# Altium schematic sheet 03_BB9900Keypad.SchDoc
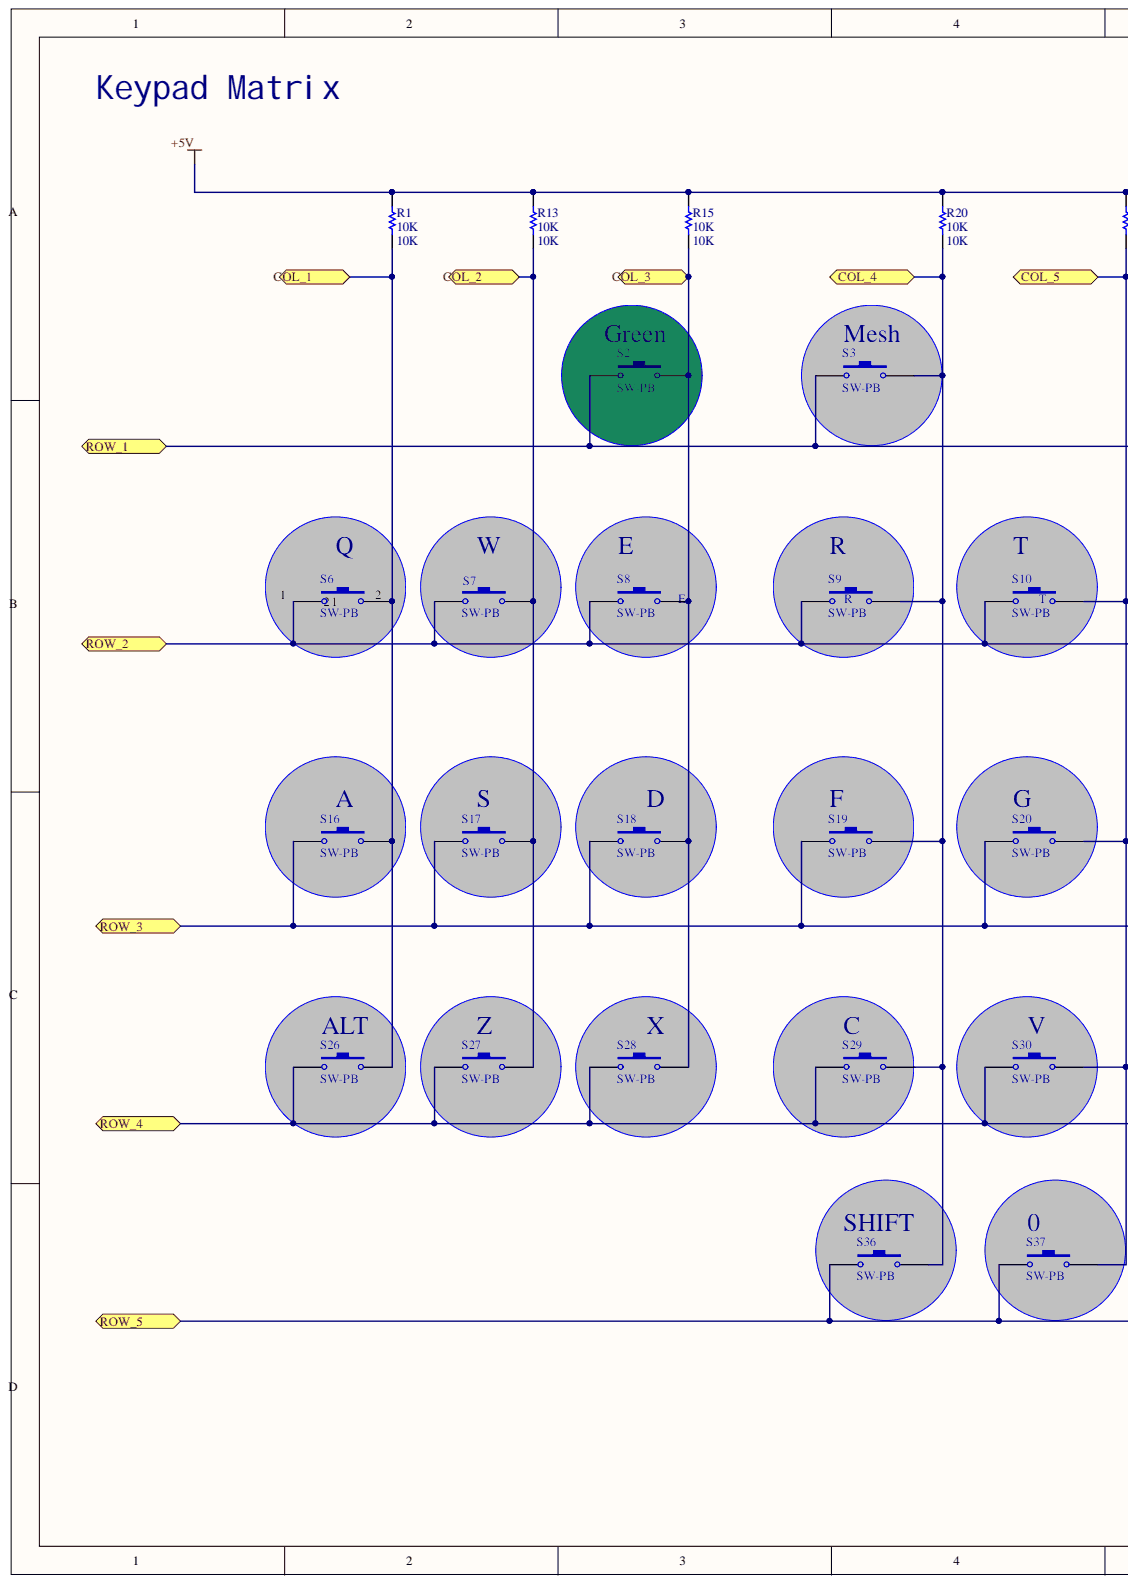
[diagram p0: 03_BB9900Keypad.SchDoc, left]
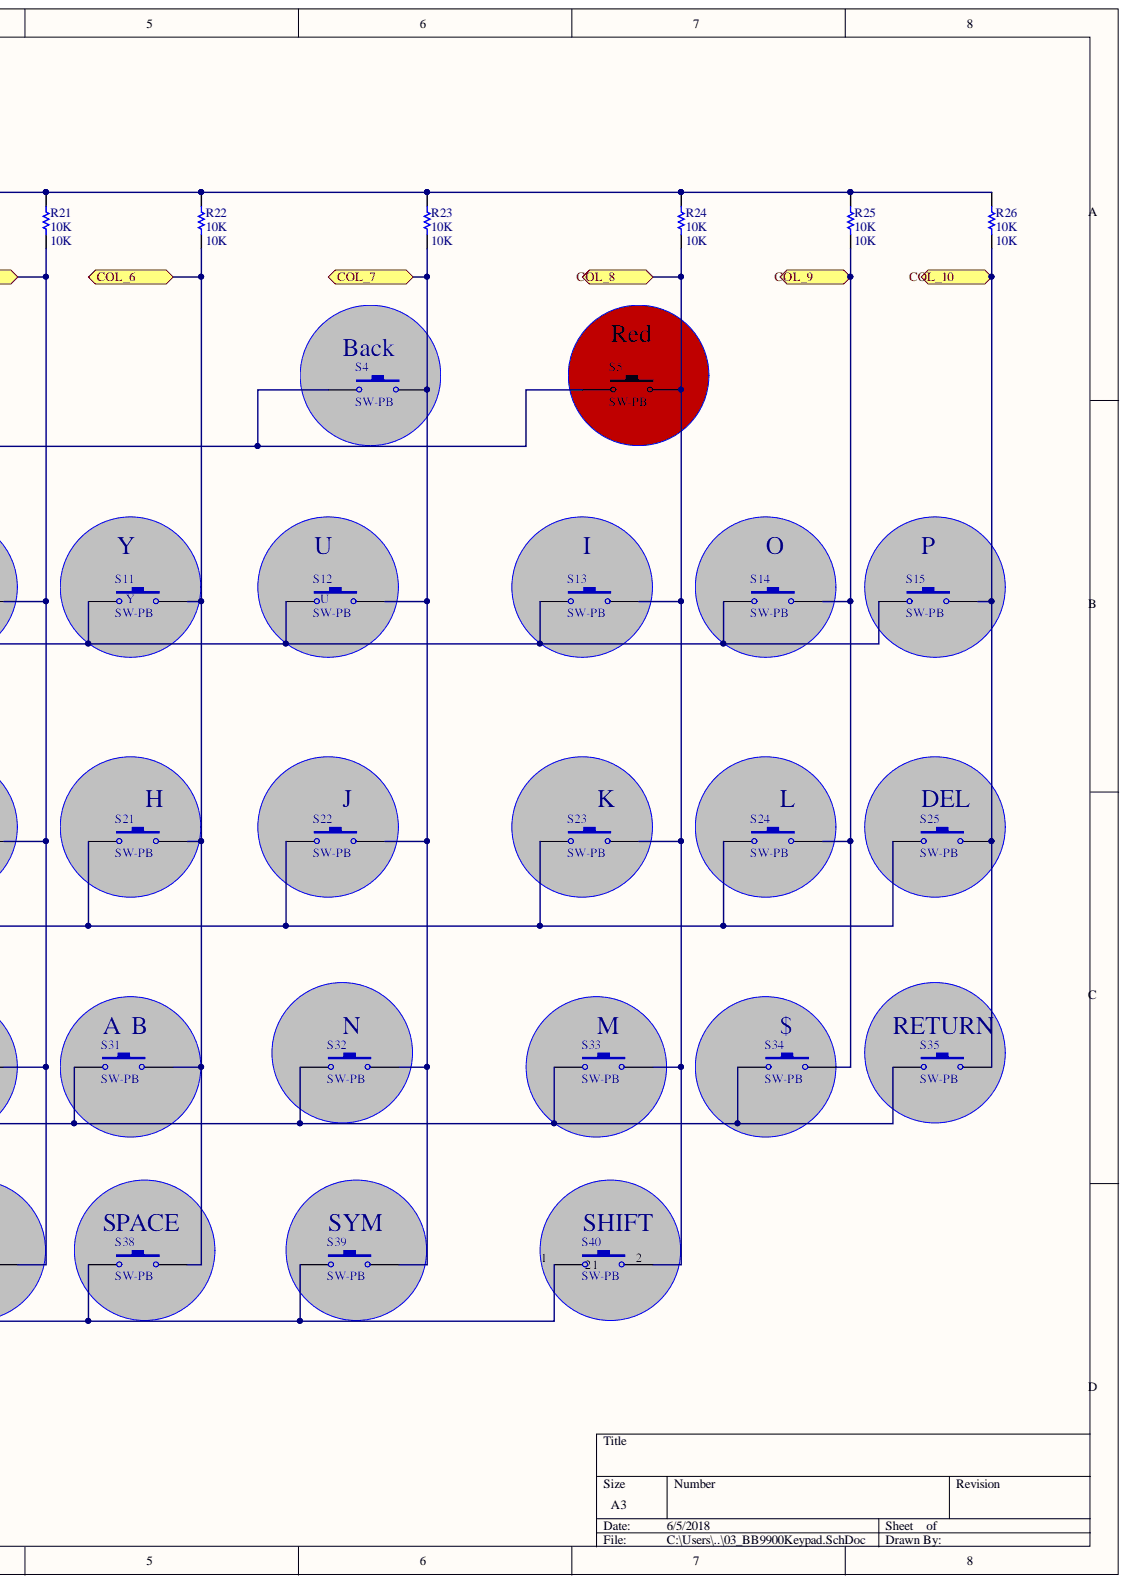
[diagram p1: 03_BB9900Keypad.SchDoc, right]

## Parts
R1  10K  [RES_0603_SMD]
R13  10K  [RES_0603_SMD]
R15  10K  [RES_0603_SMD]
R20  10K  [RES_0603_SMD]
R21  10K  [RES_0603_SMD]
R22  10K  [RES_0603_SMD]
R23  10K  [RES_0603_SMD]
R24  10K  [RES_0603_SMD]
R25  10K  [RES_0603_SMD]
R26  10K  [RES_0603_SMD]
S2  SW-PB  [Metal_dome_Tactile_SW_5mm]
S3  SW-PB  [Metal_dome_Tactile_SW_5mm]
S4  SW-PB  [Metal_dome_Tactile_SW_5mm]
S5  SW-PB  [Metal_dome_Tactile_SW_5mm]
S6  SW-PB  [Metal_dome_Tactile_SW_5mm]
S7  SW-PB  [Metal_dome_Tactile_SW_5mm]
S8  SW-PB  [Metal_dome_Tactile_SW_5mm]
S9  SW-PB  [Metal_dome_Tactile_SW_5mm]
S10  SW-PB  [Metal_dome_Tactile_SW_5mm]
S11  SW-PB  [Metal_dome_Tactile_SW_5mm]
S12  SW-PB  [Metal_dome_Tactile_SW_5mm]
S13  SW-PB  [Metal_dome_Tactile_SW_5mm]
S14  SW-PB  [Metal_dome_Tactile_SW_5mm]
S15  SW-PB  [Metal_dome_Tactile_SW_5mm]
S16  SW-PB  [Metal_dome_Tactile_SW_5mm]
S17  SW-PB  [Metal_dome_Tactile_SW_5mm]
S18  SW-PB  [Metal_dome_Tactile_SW_5mm]
S19  SW-PB  [Metal_dome_Tactile_SW_5mm]
S20  SW-PB  [Metal_dome_Tactile_SW_5mm]
S21  SW-PB  [Metal_dome_Tactile_SW_5mm]
S22  SW-PB  [Metal_dome_Tactile_SW_5mm]
S23  SW-PB  [Metal_dome_Tactile_SW_5mm]
S24  SW-PB  [Metal_dome_Tactile_SW_5mm]
S25  SW-PB  [Metal_dome_Tactile_SW_5mm]
S26  SW-PB  [Metal_dome_Tactile_SW_5mm]
S27  SW-PB  [Metal_dome_Tactile_SW_5mm]
S28  SW-PB  [Metal_dome_Tactile_SW_5mm]
S29  SW-PB  [Metal_dome_Tactile_SW_5mm]
S30  SW-PB  [Metal_dome_Tactile_SW_5mm]
S31  SW-PB  [Metal_dome_Tactile_SW_5mm]
S32  SW-PB  [Metal_dome_Tactile_SW_5mm]
S33  SW-PB  [Metal_dome_Tactile_SW_5mm]
S34  SW-PB  [Metal_dome_Tactile_SW_5mm]
S35  SW-PB  [Metal_dome_Tactile_SW_5mm]
S36  SW-PB  [Metal_dome_Tactile_SW_5mm]
S37  SW-PB  [Metal_dome_Tactile_SW_5mm]
S38  SW-PB  [Metal_dome_Tactile_SW_5mm]
S39  SW-PB  [Metal_dome_Tactile_SW_5mm]
S40  SW-PB  [Metal_dome_Tactile_SW_5mm]

## Ports
COL_1, COL_2, COL_3, COL_4, COL_5, COL_6, COL_7, COL_8, COL_9, COL_10, ROW_1, ROW_2, ROW_3, ROW_4, ROW_5

## Nets
+5V: R1.2 R13.2 R15.2 R20.2 R21.2 R22.2 R23.2 R24.2 R25.2 R26.2
COL_1: R1.1 S6.2 S16.2 S26.2 ; port COL_1
COL_2: R13.1 S7.2 S17.2 S27.2 ; port COL_2
COL_3: R15.1 S2.2 S8.2 S18.2 S28.2 ; port COL_3
COL_4: R20.1 S3.2 S9.2 S19.2 S29.2 S36.2 ; port COL_4
COL_5: R21.1 S10.2 S20.2 S30.2 S37.2 ; port COL_5
COL_6: R22.1 S11.2 S21.2 S31.2 S38.2 ; port COL_6
COL_7: R23.1 S4.2 S12.2 S22.2 S32.2 S39.2 ; port COL_7
COL_8: R24.1 S5.2 S13.2 S23.2 S33.2 S40.2 ; port COL_8
COL_9: R25.1 S14.2 S24.2 S34.2 ; port COL_9
COL_10: R26.1 S15.2 S25.2 S35.2 ; port COL_10
ROW_1: S2.1 S3.1 S4.1 S5.1 ; port ROW_1
ROW_2: S6.1 S7.1 S8.1 S9.1 S10.1 S11.1 S12.1 S13.1 S14.1 S15.1 ; port ROW_2
ROW_3: S16.1 S17.1 S18.1 S19.1 S20.1 S21.1 S22.1 S23.1 S24.1 S25.1 ; port ROW_3
ROW_4: S26.1 S27.1 S28.1 S29.1 S30.1 S31.1 S32.1 S33.1 S34.1 S35.1 ; port ROW_4
ROW_5: S36.1 S37.1 S38.1 S39.1 S40.1 ; port ROW_5

## Library data of the parts
R1 R13 R15 R20-R26 = Res1: Resistor; Component Kind: Standard; LatestRevisionDate: 17-Jul-2002; LatestRevisionNote: Re-released for DXP Platform.; Library Name: Miscellaneous Devices.IntLib; PackageDescription: Axial Device, Thru-Hole; 2 Leads; 0.3 in Pin Spacing; PackageReference: AXIAL-0.3; Published: 8-Jun-2000; Signal Integrity: Res; Simulation: RESISTOR; Value: 10K; Publisher: Altium Limited
S2-S40 = SW-PB: Switch; Component Kind: Standard; LatestRevisionDate: 17-Jul-2002; LatestRevisionNote: Re-released for DXP Platform.; Library Name: Miscellaneous Devices.IntLib; PackageDescription: Switch; 2 Leads; PackageReference: SPST-2; Published: 24-Mar-1999; Publisher: Altium Limited
